# Altium schematic sheet SD&&Others.SchDoc(SD&&Others)
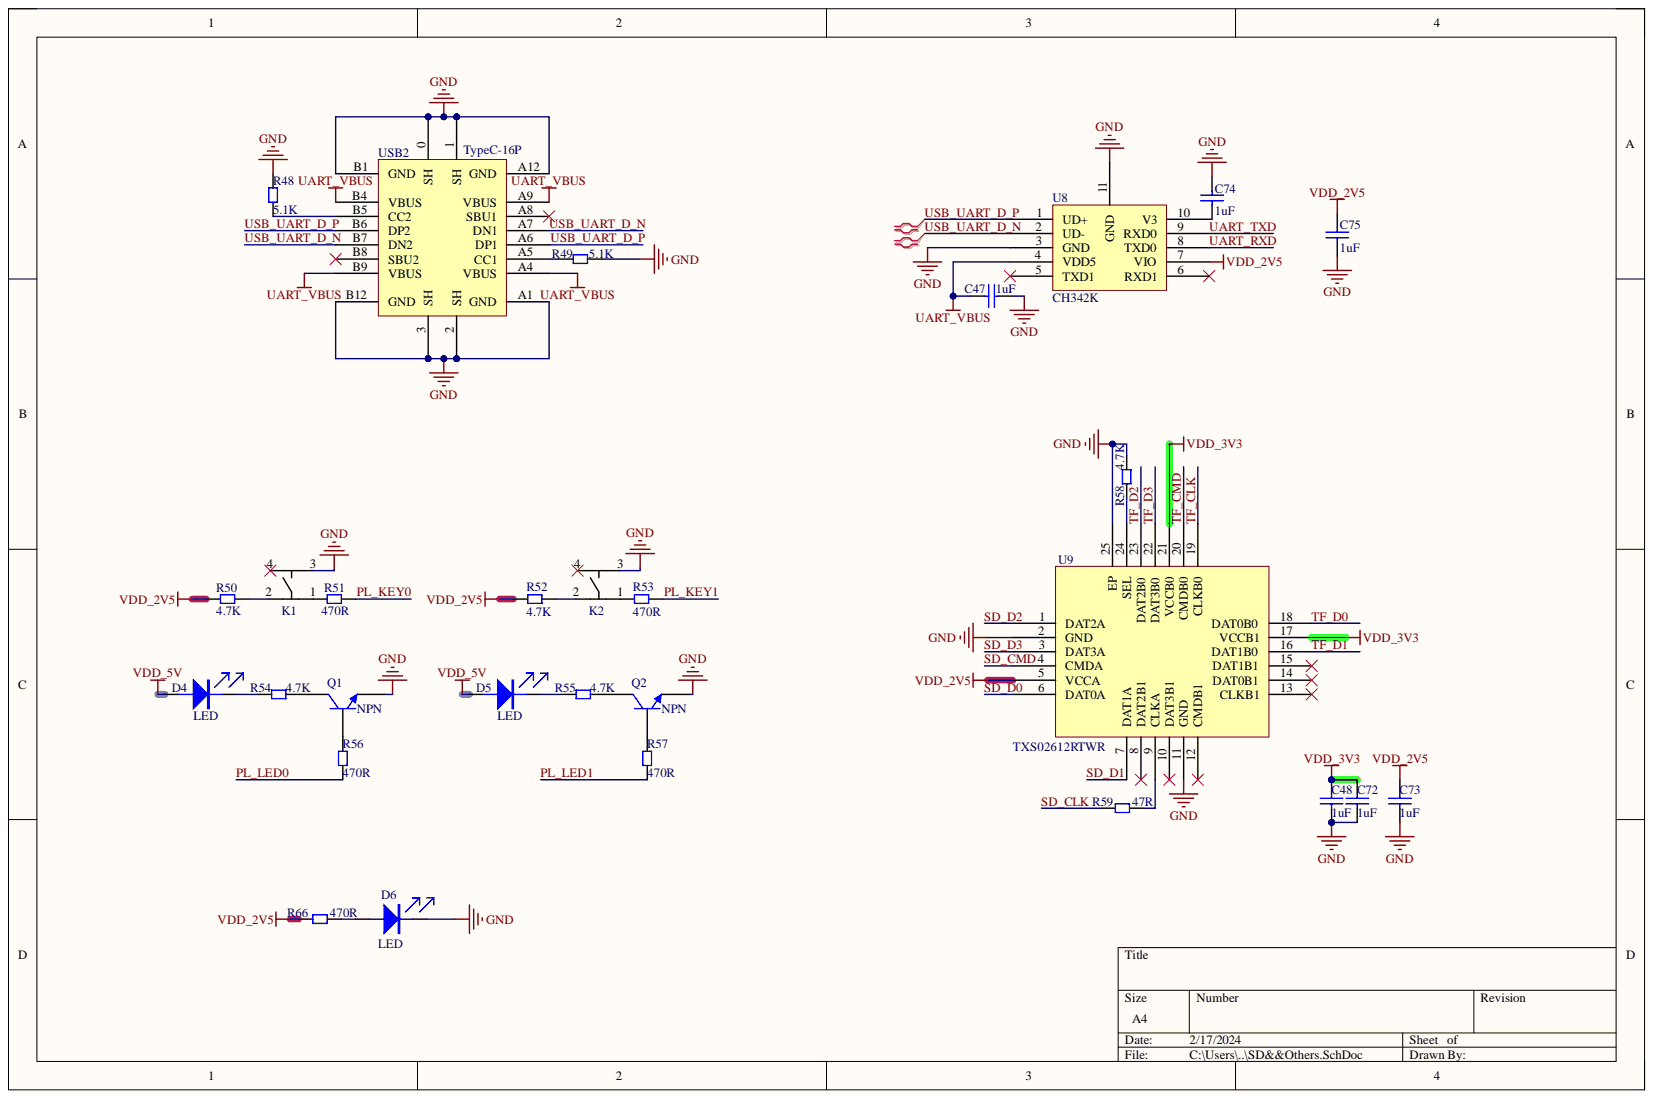
[diagram p0: SD&&Others.SchDoc]

## Parts
C47  1uF  [C0402]
C48  1uF  [C0402]
C72  1uF  [C0402]
C73  1uF  [C0402]
C74  1uF  [C0402]
C75  1uF  [C0402]
D4  LED  [LED-0603]
D5  LED  [LED-0603]
D6  LED  [LED-0603]
K1  SW_SMD4  [SW_SMD_4P]  (value not drawn)
K2  SW_SMD4  [SW_SMD_4P]  (value not drawn)
Q1  NPN  [SOT23-3]
Q2  NPN  [SOT23-3]
R48  5.1K  [R0402]
R49  5.1K  [R0402]
R50  4.7K  [R0402]
R51  470R  [R0402]
R52  4.7K  [R0402]
R53  470R  [R0402]
R54  4.7K  [R0402]
R55  4.7K  [R0402]
R56  470R  [R0402]
R57  470R  [R0402]
R58  4.7K  [R0402]
R59  47R  [R0402]
R66  470R  [R0402]
U8  CH342K  [SSOP-10_PAD]
U9  TXS02612RTWR  [WQFN-24-EP]
USB2  TypeC-16P  [TypeC-16P]

## Nets
GND: C47.2 C48.1 C72.1 C73.1 C74.1 C75.2 D6.2 K1.3 K2.3 Q1.2 Q2.2 R48.1 R49.2 R58.2 U8.3(GND) U8.11(GND) U9.2(GND) U9.11(GND) U9.25(EP) USB2.0(SH) USB2.1(SH) USB2.2(SH) USB2.3(SH) USB2.A1(GND) USB2.A12(GND) USB2.B1(GND) USB2.B12(GND)
UART_VBUS: C47.1 U8.4(VDD5) USB2.A4(VBUS) USB2.A9(VBUS) USB2.B4(VBUS) USB2.B9(VBUS)
VDD_2V5: C73.2 C75.1 R50.2 R52.2 R66.2 U8.7(VIO) U9.5(VCCA)
VDD_3V3: C48.2 C72.2 U9.17(VCCB1) U9.21(VCCB0)
VDD_5V: D4.1 D5.1
PL_KEY0: R51.1
PL_KEY1: R53.1
PL_LED0: R56.1
PL_LED1: R57.1
SD_CLK: R59.1
SD_CMD: U9.4(CMDA)
SD_D0: U9.6(DAT0A)
SD_D1: U9.7(DAT1A)
SD_D2: U9.1(DAT2A)
SD_D3: U9.3(DAT3A)
TF_CLK: U9.19(CLKB0)
TF_CMD: U9.20(CMDB0)
TF_D0: U9.18(DAT0B0)
TF_D1: U9.16(DAT1B0)
TF_D2: U9.23(DAT2B0)
TF_D3: U9.22(DAT3B0)
UART_RXD: U8.8(TXD0)
UART_TXD: U8.9(RXD0)
USB_UART_D_N: U8.2(UD-) USB2.A7(DN1) USB2.B7(DN2)
USB_UART_D_P: U8.1(UD+) USB2.A6(DP1) USB2.B6(DP2)
NetC74_2: C74.2 U8.10(V3)
NetD4_2: D4.2 R54.2
NetD5_2: D5.2 R55.2
NetD6_1: D6.1 R66.1
NetK1_1: K1.1 R51.2
NetK1_2: K1.2 R50.1
NetK2_1: K2.1 R53.2
NetK2_2: K2.2 R52.1
NetQ1_1: Q1.1 R56.2
NetQ1_3: Q1.3 R54.1
NetQ2_1: Q2.1 R57.2
NetQ2_3: Q2.3 R55.1
NetR48_2: R48.2 USB2.B5(CC2)
NetR49_1: R49.1 USB2.A5(CC1)
NetR58_1: R58.1 U9.24(SEL)
NetR59_2: R59.2 U9.9(CLKA)

Not connected: K1 4; K2 4; U8 5(TXD1) 6(RXD1); U9 8(DAT2B1) 10(DAT3B1) 12(CMDB1) 13(CLKB1) 14(DAT0B1) 15(DAT1B1); USB2 A8(SBU1) B8(SBU2)

## Library data of the parts
C47 C48 C72-C75 = Cap_NP: CAP no polarity; Component Kind: Standard; Library Name: Common.SchLib
K1 K2 = SW_SMD4: SMD Switch4, C2686829; Component Kind: Standard; Library Name: Common.SchLib
R48-R59 R66 = Res: Component Kind: Standard; Description: Res; Library Name: Common.SchLib
U8 = CH342K: USB to UART; Component Kind: Standard; Library Name: ZYNQ_SDR.SCHLIB
U9 = TXS02612RTWR: Voltage level conversion; Component Kind: Standard; Library Name: ZYNQ_SDR.SCHLIB
D4-D6 = LED: Component Kind: Standard; Description: LED; Library Name: Common.SchLib
Q1 Q2 = NPN: Component Kind: Standard; Description: NPN; Library Name: Common.SchLib
USB2 = TypeC-16P: Component Kind: Standard; Description: TypeC-16P; Library Name: Common.SchLib
